# Altium schematic sheet ethernet.SchDoc(ethernet)
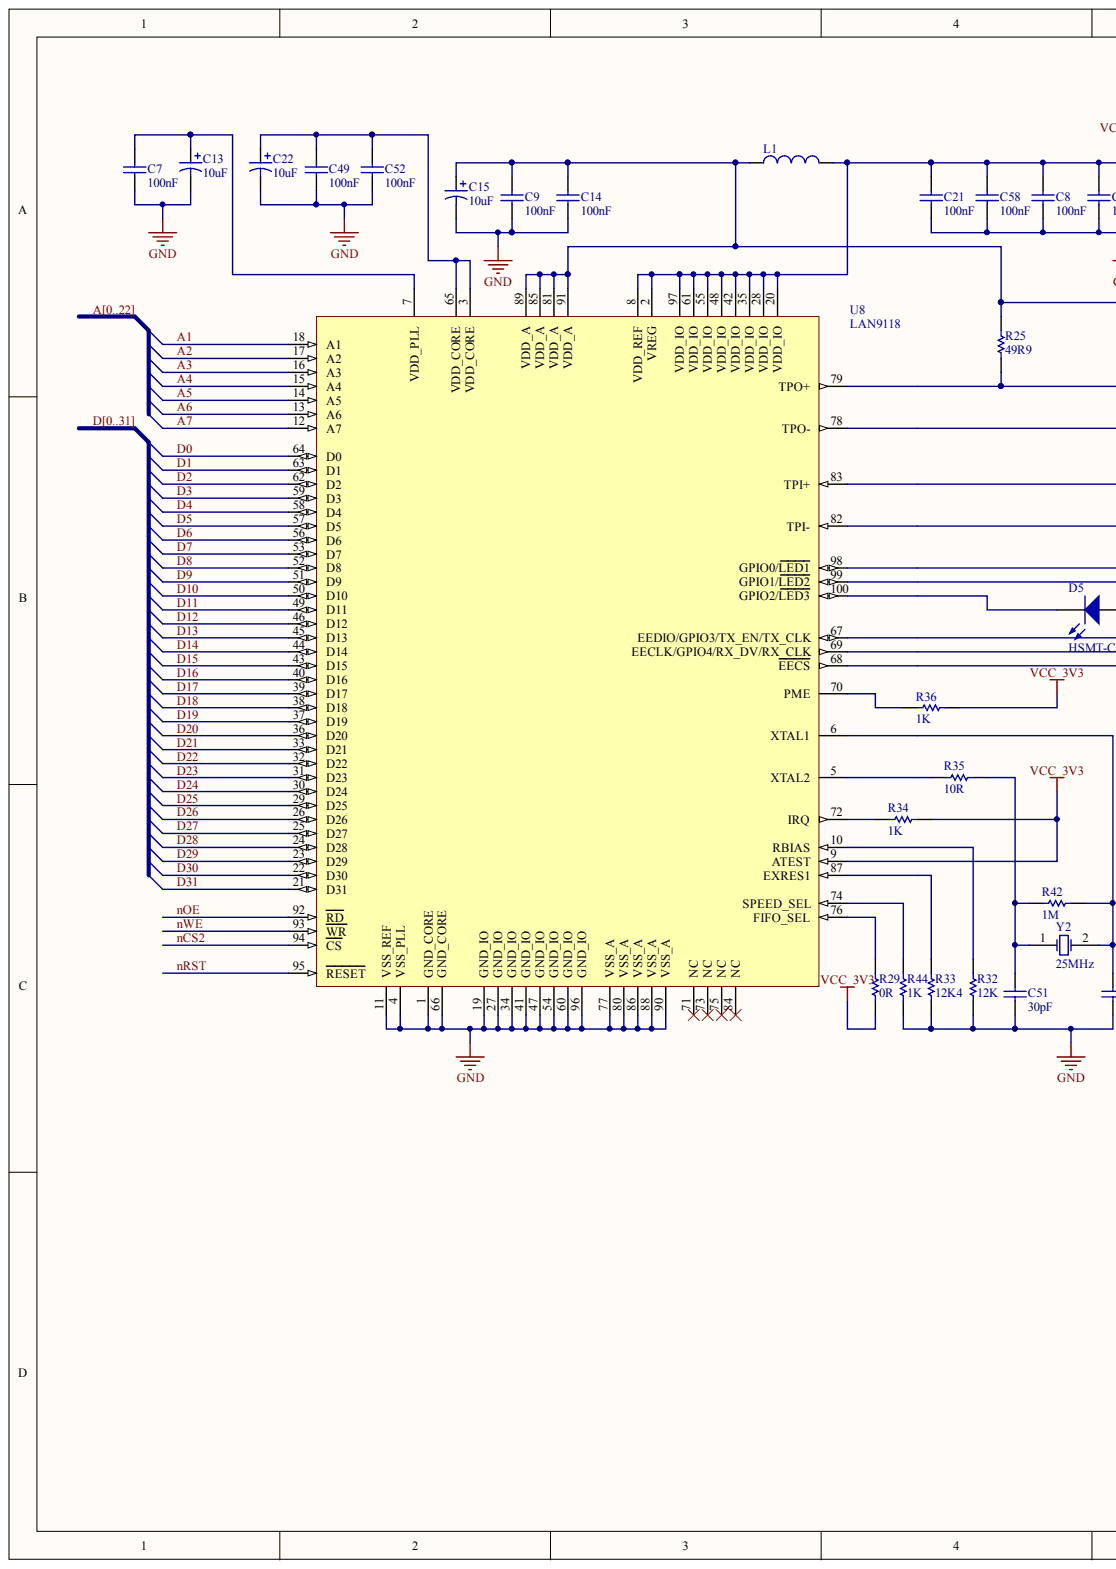
[diagram p0: ethernet.SchDoc, left]
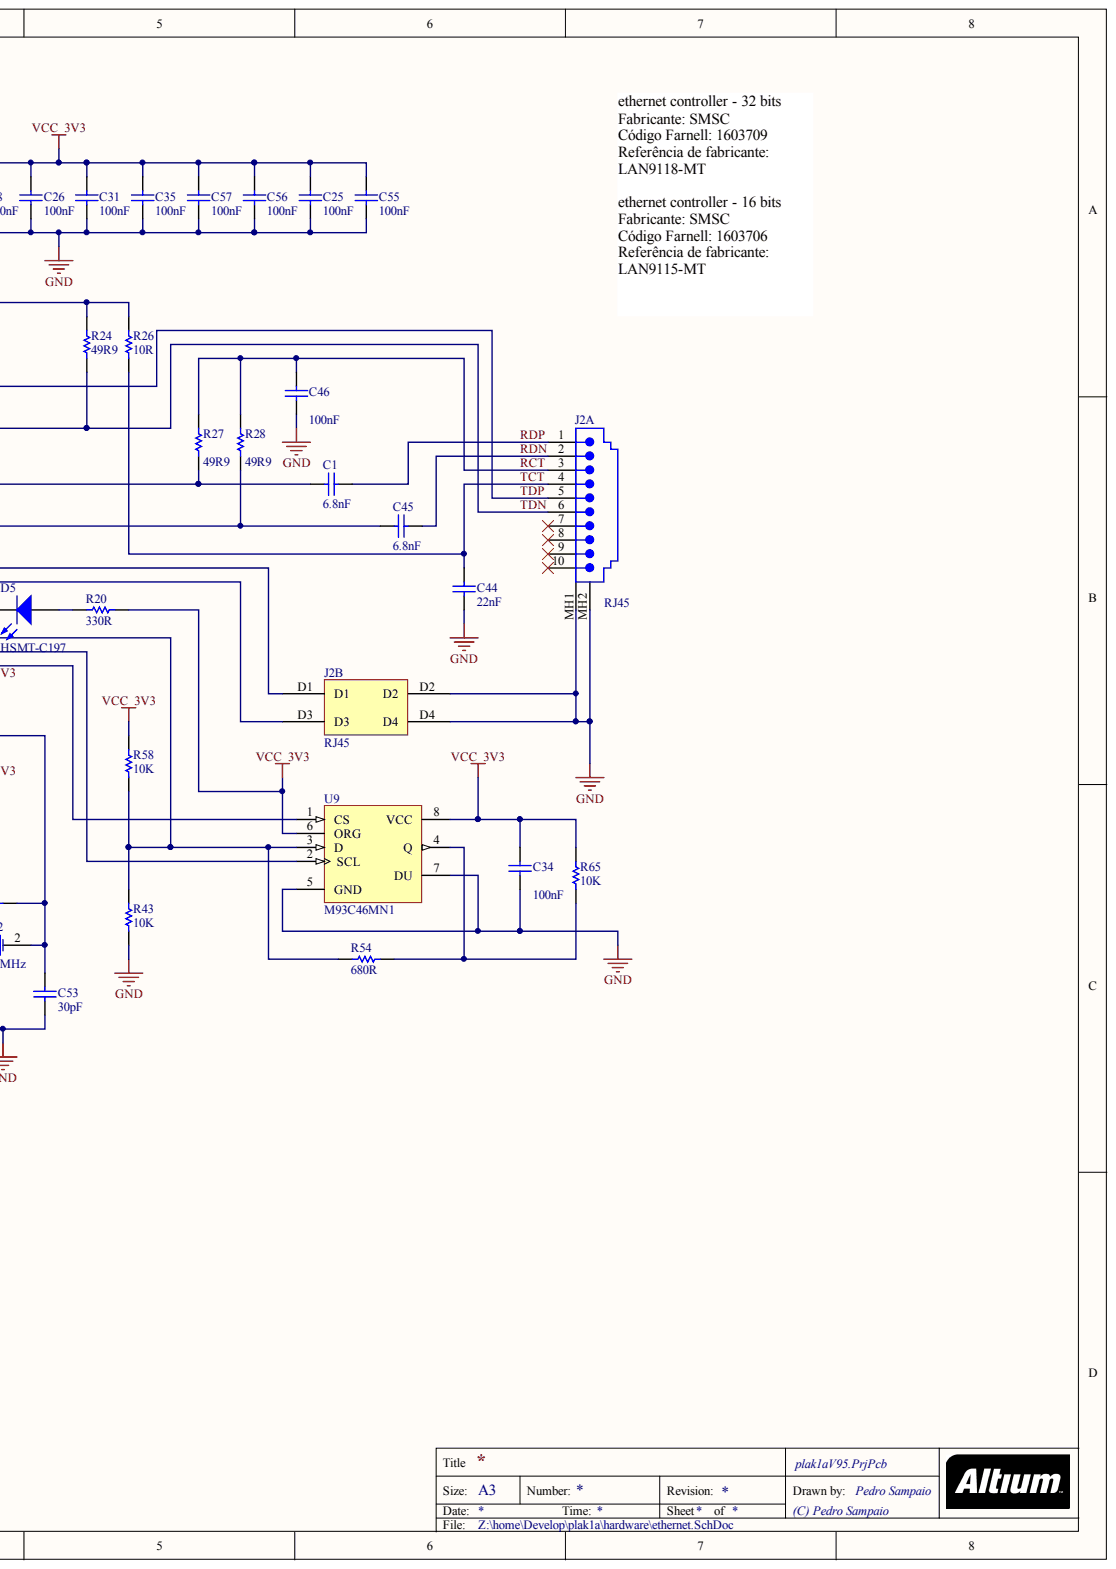
[diagram p1: ethernet.SchDoc, right]

## Parts
C1  value 6.8nF  [0805]  (hidden comment: C0805)
C7  value 100nF  [0603]  (hidden comment: C0603)
C8  value 100nF  [0603]  (hidden comment: C0603)
C9  value 100nF  [0603]  (hidden comment: C0603)
C13  value 10uF  [B]  (hidden comment: T491B)
C14  value 100nF  [0603]  (hidden comment: C0603)
C15  value 10uF  [B]  (hidden comment: T491B)
C21  value 100nF  [0603]  (hidden comment: C0603)
C22  value 10uF  [B]  (hidden comment: T491B)
C25  value 100nF  [0603]  (hidden comment: C0603)
C26  value 100nF  [0603]  (hidden comment: C0603)
C31  value 100nF  [0603]  (hidden comment: C0603)
C34  value 100nF  [0603]  (hidden comment: C0603)
C35  value 100nF  [0603]  (hidden comment: C0603)
C44  value 22nF  [0805]  (hidden comment: C0805)
C45  value 6.8nF  [0805]  (hidden comment: C0805)
C46  value 100nF  [0603]  (hidden comment: C0603)
C49  value 100nF  [0603]  (hidden comment: C0603)
C51  value 30pF  [0805]  (hidden comment: C0805)
C52  value 100nF  [0603]  (hidden comment: C0603)
C53  value 30pF  [0805]  (hidden comment: C0805)
C55  value 100nF  [0603]  (hidden comment: C0603)
C56  value 100nF  [0603]  (hidden comment: C0603)
C57  value 100nF  [0603]  (hidden comment: C0603)
C58  value 100nF  [0603]  (hidden comment: C0603)
D5  HSMT-C197  [1.6X0.8X0.4]
J2  RJ45  [RJ45]  (parts A,B)
L1  Ferrite  [L0603]  (value not drawn)
R20  value 330R  [3216[1206]]  (hidden comment: Res Semi)
R24  value 49R9  [3216[1206]]  (hidden comment: Res Semi)
R25  value 49R9  [3216[1206]]  (hidden comment: Res Semi)
R26  value 10R  [3216[1206]]  (hidden comment: Res Semi)
R27  value 49R9  [3216[1206]]  (hidden comment: Res Semi)
R28  value 49R9  [3216[1206]]  (hidden comment: Res Semi)
R29  value 0R  [3216[1206]]  (hidden comment: Res Semi)
R32  value 12K  [3216[1206]]  (hidden comment: Res Semi)
R33  value 12K4  [3216[1206]]  (hidden comment: Res Semi)
R34  value 1K  [3216[1206]]  (hidden comment: Res Semi)
R35  value 10R  [3216[1206]]  (hidden comment: Res Semi)
R36  value 1K  [3216[1206]]  (hidden comment: Res Semi)
R42  value 1M  [3216[1206]]  (hidden comment: Res Semi)
R43  value 10K  [3216[1206]]  (hidden comment: Res Semi)
R44  value 1K  [3216[1206]]  (hidden comment: Res Semi)
R54  value 680R  [3216[1206]]  (hidden comment: Res Semi)
R58  value 10K  [3216[1206]]  (hidden comment: Res Semi)
R65  value 10K  [3216[1206]]  (hidden comment: Res Semi)
U8  LAN9118  [TQFP100_N]
U9  M93C46MN1  [SOP8_L]
Y2  25MHz  [CS10]

## Nets
GND: C7.1 C8.1 C9.1 C13.2 C14.1 C15.2 C21.1 C22.2 C25.1 C26.1 C31.1 C34.1 C35.1 C44.1 C46.1 C49.1 C51.1 C52.1 C53.1 C55.1 C56.1 C57.1 C58.1 J2.D2 J2.D4 J2.MH1 J2.MH2 R32.1 R33.1 R43.1 R44.1 U8.1(GND_CORE) U8.4(VSS_PLL) U8.11(VSS_REF) U8.19(GND_IO) U8.27(GND_IO) U8.34(GND_IO) U8.41(GND_IO) U8.47(GND_IO) U8.54(GND_IO) U8.60(GND_IO) U8.66(GND_CORE) U8.77(VSS_A) U8.80(VSS_A) U8.86(VSS_A) U8.88(VSS_A) U8.90(VSS_A) U8.96(GND_IO) U9.5(GND) U9.7(DU)
VCC_3V3: C8.2 C21.2 C25.2 C26.2 C31.2 C34.2 C35.2 C55.2 C56.2 C57.2 C58.2 L1.2 R20.2 R29.1 R34.2 R36.2 R58.2 R65.2 U8.2(VREG) U8.8(VDD_REF) U8.9(ATEST) U8.20(VDD_IO) U8.28(VDD_IO) U8.35(VDD_IO) U8.42(VDD_IO) U8.48(VDD_IO) U8.55(VDD_IO) U8.61(VDD_IO) U8.97(VDD_IO) U9.6(ORG) U9.8(VCC)
A1: U8.18(A1)  [also labelled A]
A2: U8.17(A2)  [also labelled A]
A3: U8.16(A3)  [also labelled A]
A4: U8.15(A4)  [also labelled A]
A5: U8.14(A5)  [also labelled A]
A6: U8.13(A6)  [also labelled A]
A7: U8.12(A7)  [also labelled A]
D0: U8.64(D0)  [also labelled D]
D1: U8.63(D1)  [also labelled D]
D2: U8.62(D2)  [also labelled D]
D3: U8.59(D3)  [also labelled D]
D4: U8.58(D4)  [also labelled D]
D5: U8.57(D5)  [also labelled D]
D6: U8.56(D6)  [also labelled D]
D7: U8.53(D7)  [also labelled D]
D8: U8.52(D8)  [also labelled D]
D9: U8.51(D9)  [also labelled D]
D10: U8.50(D10)  [also labelled D]
D11: U8.49(D11)  [also labelled D]
D12: U8.46(D12)  [also labelled D]
D13: U8.45(D13)  [also labelled D]
D14: U8.44(D14)  [also labelled D]
D15: U8.43(D15)  [also labelled D]
D16: U8.40(D16)  [also labelled D]
D17: U8.39(D17)  [also labelled D]
D18: U8.38(D18)  [also labelled D]
D19: U8.37(D19)  [also labelled D]
D20: U8.36(D20)  [also labelled D]
D21: U8.33(D21)  [also labelled D]
D22: U8.32(D22)  [also labelled D]
D23: U8.31(D23)  [also labelled D]
D24: U8.30(D24)  [also labelled D]
D25: U8.29(D25)  [also labelled D]
D26: U8.26(D26)  [also labelled D]
D27: U8.25(D27)  [also labelled D]
D28: U8.24(D28)  [also labelled D]
D29: U8.23(D29)  [also labelled D]
D30: U8.22(D30)  [also labelled D]
D31: U8.21(D31)  [also labelled D]
NCS2: U8.94(CS)
NOE: U8.92(RD)
NRST: U8.95(RESET)
NWE: U8.93(WR)
RCT: C46.2 J2.3 R27.2 R28.2
RDN: C45.2 J2.2
RDP: C1.2 J2.1
TCT: C44.2 J2.4 R26.1
TDN: J2.6 R24.1 U8.78(TPO-)
TDP: J2.5 R25.1 U8.79(TPO+)
NetC1_1: C1.1 R27.1 U8.83(TPI+)
NetC7_2: C7.2 C13.1 U8.7(VDD_PLL)
NetC9_2: C9.2 C14.2 C15.1 L1.1 R24.2 R25.2 R26.2 U8.81(VDD_A) U8.85(VDD_A) U8.89(VDD_A) U8.91(VDD_A)
NetC22_1: C22.1 C49.2 C52.2 U8.3(VDD_CORE) U8.65(VDD_CORE)
NetC45_1: C45.1 R28.1 U8.82(TPI-)
NetC51_2: C51.2 R35.2 R42.1 Y2.1
NetC53_2: C53.2 R42.2 U8.6(XTAL1) Y2.2
NetD5_1: D5.1 R20.1
NetD5_2: D5.2 U8.100(GPIO2/LED3)
NetJ2_D1: J2.D1 U8.98(GPIO0/LED1)
NetJ2_D3: J2.D3 U8.99(GPIO1/LED2)
NetR29_2: R29.2 U8.76(FIFO_SEL)
NetR32_2: R32.2 U8.10(RBIAS)
NetR33_2: R33.2 U8.87(EXRES1)
NetR34_1: R34.1 U8.72(IRQ)
NetR35_1: R35.1 U8.5(XTAL2)
NetR36_1: R36.1 U8.70(PME)
NetR43_2: R43.2 R54.1 R58.1 U8.67(EEDIO/GPIO3/TX_EN/TX_CLK) U9.3(D)
NetR44_2: R44.2 U8.74(SPEED_SEL)
NetR54_2: R54.2 R65.1 U9.4(Q)
NetU8_68: U8.68(EECS) U9.1(CS)
NetU8_69: U8.69(EECLK/GPIO4/RX_DV/RX_CLK) U9.2(SCL)
A0:  [also labelled A]
A8:  [also labelled A]
A9:  [also labelled A]
A10:  [also labelled A]
A11:  [also labelled A]
A12:  [also labelled A]
A13:  [also labelled A]
A14:  [also labelled A]
A15:  [also labelled A]
A16:  [also labelled A]
A17:  [also labelled A]
A18:  [also labelled A]
A19:  [also labelled A]
A20:  [also labelled A]
A21:  [also labelled A]
A22:  [also labelled A]

Not connected: J2 7 8 9 10; U8 71(NC) 73(NC) 75(NC) 84(NC)

## Library data of the parts
C1 C44 C45 C51 C53 = C0805: Ceramic Chip Capacitor - Standard; Note: Footprint corresponds to dimensions for bulk cassette packaging. This case size has tightened tolerances.; Code_IPC: 2012[0805]; Code_JEITA: 0805; Component Kind: Standard; ComponentLink1Description: Manufacturer Link; ComponentLink1URL: http://www.kemet.com/; ComponentLink2Description: Datasheet; ComponentLink2URL: http://www.kemet.com/kemet/web/homepage/kechome.nsf/vapubfilesname/F3102TA.pdf/$file/F3102TA.pdf; DatasheetDocument: Mar-2001; LatestRevisionDate: 19-Mar-2004; LatestRevisionNote: Silkscreen size reduced.; Library Name: KEMET Chip Capacitor.IntLib; PackageDocument: Mar-2001; PackageReference: 0805; Published: 17-Jul-2002; Signal Integrity: C0805; Simulation: CAP; Publisher: Altium Limited
  C1 C45: Farnell: 1414700
  C44: Farnell: 1288269
  C51 C53: Farnell: 1650761
C7-C9 C14 C21 C25 C26 C31 C34 C35 C46 C49 C52 C55-C58 = C0603: Ceramic Chip Capacitor - Standard; Note: Footprint corresponds to dimensions for bulk cassette packaging. This case size has tightened tolerances.; Code_IPC: 1608 [0603]; Code_JEITA: 0603; Component Kind: Standard; ComponentLink1Description: Manufacturer Link; ComponentLink1URL: http://www.kemet.com/; ComponentLink2Description: Datasheet; ComponentLink2URL: http://www.kemet.com/kemet/web/homepage/kechome.nsf/vapubfilesname/F3102TA.pdf/$file/F3102TA.pdf; DatasheetDocument: Mar-2001; Farnell: 1301716; LatestRevisionDate: 19-Mar-2004; LatestRevisionNote: Silkscreen size reduced.; Library Name: KEMET Chip Capacitor.IntLib; PackageDocument: Mar-2001; PackageReference: 0603; Published: 17-Jul-2002; Signal Integrity: C0603; Simulation: CAP; Publisher: Altium Limited
C13 C15 C22 = T491B: Solid Tantalum Chip Capacitor, Standard T491 Series - Industrial Grade; Code_JEITA: 3528-21; Component Kind: Standard; ComponentLink1Description: Manufacturer Link; ComponentLink1URL: http://www.kemet.com/; ComponentLink2Description: Datasheet; ComponentLink2URL: http://www.kemet.com/kemet/web/homepage/kechome.nsf/vapubfilesname/F3102TA.pdf/$file/F3102TA.pdf; DatasheetDocument: Mar-2001; Farnell: 1301818; LatestRevisionDate: 19-Mar-2004; LatestRevisionNote: Silkscreen dimensions re-sized.; Library Name: KEMET Chip Capacitor.IntLib; PackageDocument: Mar-2001; PackageReference: B; Published: 17-Jul-2002; Signal Integrity: T491B; Simulation: CAP; Publisher: Altium Limited
D5 = HSMT-C197: Low Profile Chip LED, Red; LuminousIntensity: 15mcd (Minimum).; PCB_Layout: Complies with manufacturer's recommendation.; Component Kind: Standard; ComponentLink1Description: Manufacturer Link; ComponentLink1URL: http://www.agilent.com/; ComponentLink2Description: Datasheet; ComponentLink2URL: http://cp.literature.agilent.com/litweb/pdf/5988-5501EN.pdf; DatasheetDocument: 27-Jan-2002; LED_Colour: Red; Library Name: Agilent Optoelectronic LED.IntLib; PackageDocument: 27-Jan-2002; PackageReference: 1.6X0.8X0.4; Published: 11-Dec-2002; Signal Integrity: LED; Simulation: Agl_HSMCC177; Publisher: Altium Limited
J2 = RJ45: Right Angle, Ultra Low Profile PCB Jack, with no Panel Grounding Tabs, Shielded Version; DrillSize: Nominal, Rounded to nearest 0.05mm; PCB_Layout: Complies with Manufacturer's Recommendation.; PCB_Mounting: Thru-Hole; PerformanceCategory: 3/4; Ports: 1; Positions/Loaded: Port1 - 8/10; Component Kind: Standard; ComponentLink1Description: Manufacturer Link; ComponentLink1URL: http://www.molex.com/; ComponentLink2Description: Datasheet; ComponentLink2URL: http://www.molex.com/cmc_upload/common_files/1212003_43202catalogpage.pdf; DatasheetDocument: 18-Dec-2001; Farnell: 1284349; Library Name: Componentes.IntLib; PackageDocument: 18-Dec-2001; PackageReference: 43202-8908; Published: 25-Jun-2002; Signal Integrity: Modular Jack; Publisher: Altium Limited
L1 = Inductor: Code_IEC: 1005; Value: 10mH; Code_JEITA: 0402; Component Kind: Standard; Description: Inductor; Farnell: 1308766; LatestRevisionDate: 17-Jul-2002; LatestRevisionNote: Re-released for DXP Platform.; Library Name: Miscellaneous Devices.IntLib; PackageReference: 0402-A; Published: 8-Jun-2000; Signal Integrity: Inductor; Simulation: INDUCTOR; Publisher: Altium Limited
R20 R24-R29 R32-R36 R42-R44 R54 R58 R65 = Res Semi: Semiconductor Resistor; Component Kind: Standard; LatestRevisionDate: 17-Jul-2002; LatestRevisionNote: Re-released for DXP Platform.; Library Name: Miscellaneous Devices.IntLib; PackageReference: AXIAL-0.5; Published: 8-Jun-2000; Signal Integrity: Res Semi; Simulation: RES; Publisher: Altium Limited
  R20: Farnell: 1527413
  R24 R25 R27 R28: Farnell: 1575515
  R26 R35: Farnell: 9233768
  R29: Farnell: 9240489
  R32: Farnell: 1577620
  R33: Farnell: 1575913
  R34 R36 R44: Farnell: 9234004
  R42: Farnell: 1376994
  R43 R58 R65: Farnell: 9240640
  R54: Farnell: 1500731
U8 = LAN9118: High Performance Single-Chip 10/100 Non-PCI Ethernet Controller; Component Kind: Standard; Farnell: 1603709; Library Name: Componentes.SchLib
U9 = M93C46MN1: 1Kb (x8/x16) Serial Microwire Bus EEPROM; Note: Do Not Use pin number 7 (DU).; Component Kind: Standard; ComponentLink1Description: Manufacturer Link; ComponentLink1URL: http://www.st.com/; ComponentLink2Description: Datasheet; ComponentLink2URL: http://www.st.com/stonline/products/literature/ds/4997/m93c46.pdf; DatasheetVersion: Feb-1999; Farnell: 9758208; LatestRevisionDate: 13-Apr-2006; LatestRevisionNote: IPC-7351 Footprint Added.; Library Name: ST Memory EEPROM Serial.IntLib; PackageDescription: 8-Pin Small Outline Integrated Circuit 1.27 mm Pitch; PackageReference: SOP8; PackageVersion: Jul-1999; PCB3D: SOP8; Published: 24-Aug-2001; Publisher: Altium Limited
Y2 = HC49/4H_SMX: Surface Mount Quartz Crystal; Note: PCB layout complies with manufacturer's recommendation.; Component Kind: Standard; ComponentLink1Description: Manufacturer Link; ComponentLink1URL: http://www.cmac.com/mt/; ComponentLink2Description: Datasheet; ComponentLink2URL: http://www.cmac.com/mt/databook/crystals/smd/hc49_4h_smx.pdf; DatasheetDocument: 18-Oct-1999; Farnell: 1457191; Library Name: C-MAC Crystal Oscillator.IntLib; PackageDocument: 18-Oct-1999; PackageReference: HC49/4H_SMX; Published: 17-Jul-2002; Publisher: Altium Limited
